# Altium schematic sheet main.SchDoc(main)
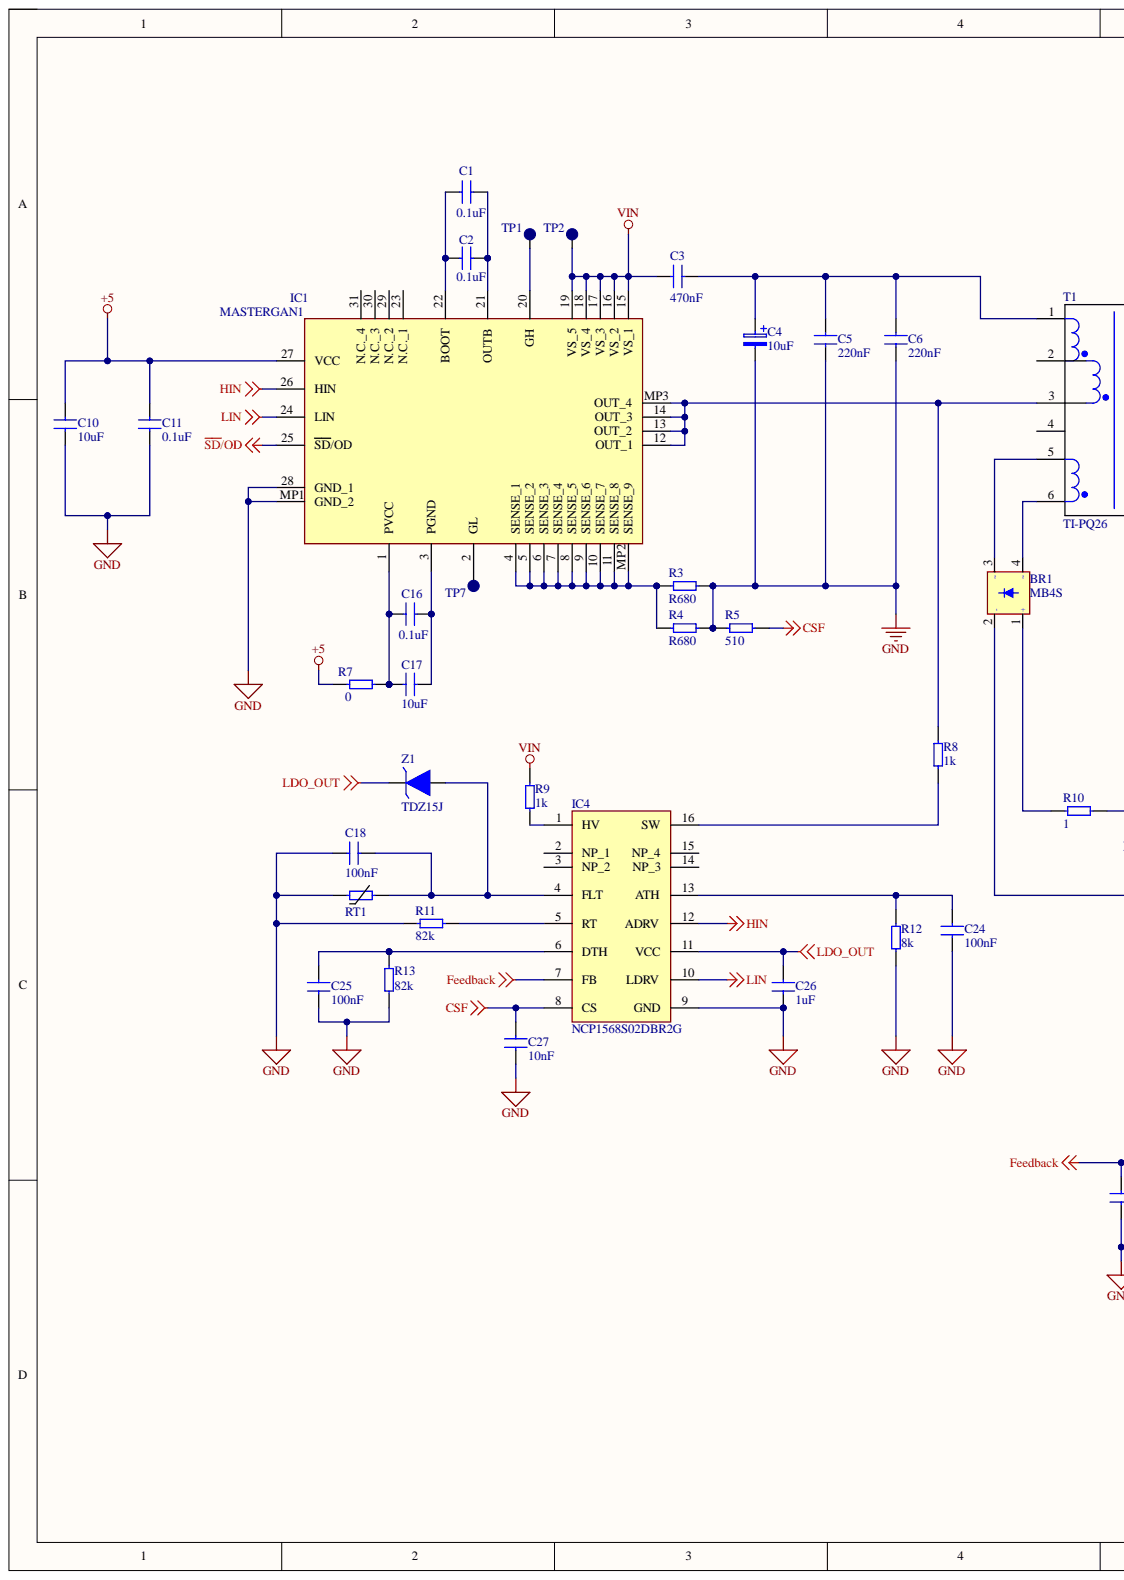
[diagram p0: main.SchDoc, left]
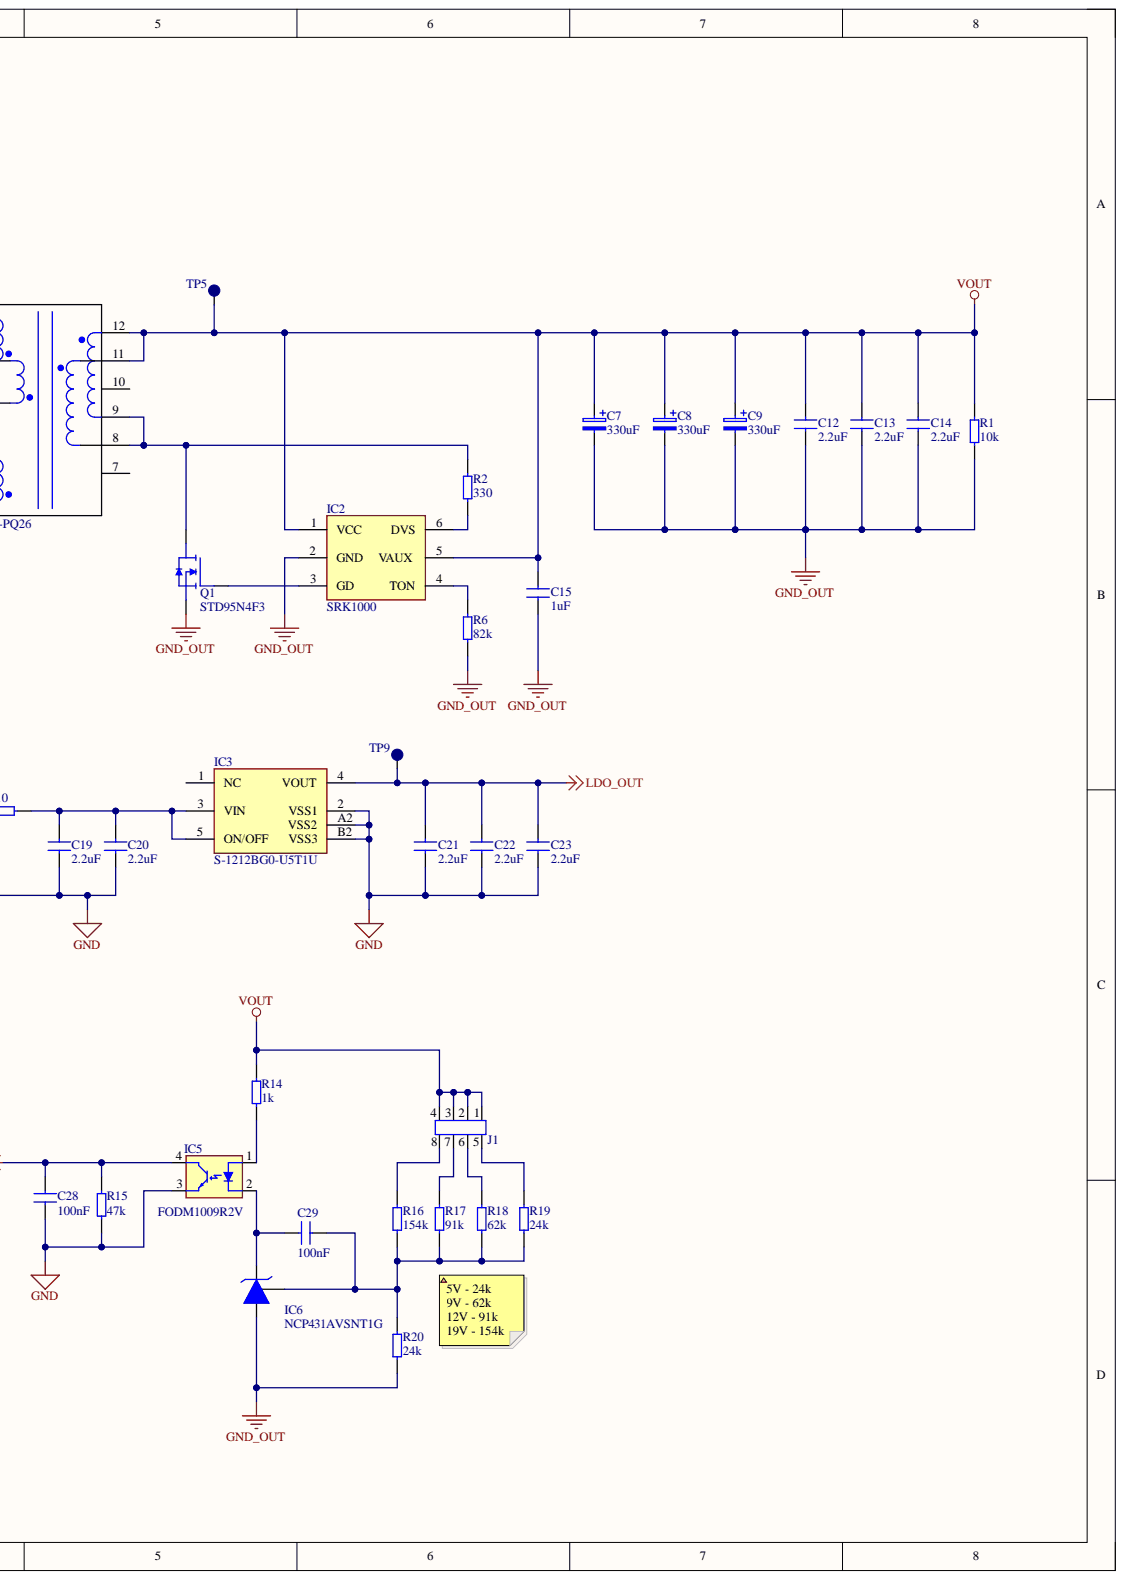
[diagram p1: main.SchDoc, right]

## Parts
BR1  MB4S  [SOP245P670X290-4N]
C1  0.1uF  [Capcitor_1206]
C2  0.1uF  [Capcitor_1206]
C3  470nF  [Capacitor_1812]
C4  10uF  [Capacitor_Electrolytic]
C5  220nF  [Capacitor_1812]
C6  220nF  [Capacitor_1812]
C7  330uF  [Capacitor_Electrolytic]
C8  330uF  [Capacitor_Electrolytic]
C9  330uF  [Capacitor_Electrolytic]
C10  10uF  [Capcitor_1206]
C11  0.1uF  [Capcitor_1206]
C12  2.2uF  [Capcitor_1206]
C13  2.2uF  [Capcitor_1206]
C14  2.2uF  [Capcitor_1206]
C15  1uF  [Capcitor_1206]
C16  0.1uF  [Capcitor_1206]
C17  10uF  [Capcitor_1206]
C18  100nF  [Capcitor_1206]
C19  2.2uF  [Capcitor_1206]
C20  2.2uF  [Capcitor_1206]
C21  2.2uF  [Capcitor_1206]
C22  2.2uF  [Capcitor_1206]
C23  2.2uF  [Capcitor_1206]
C24  100nF  [Capcitor_1206]
C25  100nF  [Capcitor_1206]
C26  1uF  [Capacitor_0805]
C27  10nF  [Capcitor_1206]
C28  100nF  [Capcitor_1206]
C29  100nF  [Capcitor_1206]
IC1  MASTERGAN1  [MASTERGAN1]
IC2  SRK1000  [SOT95P280X145-6N]
IC3  S-1212BG0-U5T1U  [S1212BG0U5T1U]
IC4  NCP1568S02DBR2G  [SOIC127P600X175-16N]
IC5  FODM1009R2V  [FODM1009R2V]
IC6  NCP431AVSNT1G  [SOT96P237X111-3N]
J1  Header_2x4  [Header_2x4]  (value not drawn)
Q1  STD95N4F3  [STD95N4F3]
R1  10k  [Resistor_1206]
R2  330  [Resistor_1206]
R3  R680  [Resistor_1206]
R4  R680  [Resistor_1206]
R5  510  [Resistor_1206]
R6  82k  [Resistor_1206]
R7  0  [Resistor_0805]
R8  1k  [Resistor_1206]
R9  1k  [Resistor_1206]
R10  1  [Resistor_1206]
R11  82k  [Resistor_1206]
R12  8k  [Resistor_1206]
R13  82k  [Resistor_1206]
R14  1k  [Resistor_1206]
R15  47k  [Resistor_1206]
R16  154k  [Resistor_0805]
R17  91k  [Resistor_0805]
R18  62k  [Resistor_0805]
R19  24k  [Resistor_0805]
R20  24k  [Resistor_1206]
RT1  NTCG104EF104FT1SX  [THRMC1005X55N]  (value not drawn)
T1  TI-PQ26  [PQ26-20-K]
TP1  =Value  [Test point]  (no BOM)
TP2  =Value  [Test point]  (no BOM)
TP5  =Value  [Test point]  (no BOM)
TP7  =Value  [Test point]  (no BOM)
TP9  =Value  [Test point]  (no BOM)
Z1  TDZ15J  [SODFL4725X105N]

## Nets
+5: C10.2 C11.1 IC1.27(VCC) R7.1
~{SD}/OD: IC1.25(SD/OD)
CSF: C27.1 IC4.8(CS) R5.1
Feedback: C28.2 IC4.7(FB) IC5.4 R15.2
GND: BR1.2(-) C4.2 C5.2 C6.1 C10.1 C11.2 C18.1 C19.1 C20.1 C21.1 C22.1 C23.1 C24.1 C25.2 C26.1 C27.2 C28.1 IC1.28(GND_1) IC1.MP1(GND_2) IC3.2(VSS1) IC3.A2(VSS2) IC3.B2(VSS3) IC4.9(GND) IC5.3 R3.2 R4.2 R5.2 R11.2 R12.1 R13.2 R15.1 RT1.1
GND_OUT: C7.2 C8.2 C9.2 C12.1 C13.1 C14.1 C15.1 IC2.2(GND) IC6.3 Q1.2 R1.1 R6.1 R20.1
HIN: IC1.26(HIN) IC4.12(ADRV)
LDO_OUT: C21.2 C22.2 C23.2 C26.2 IC3.4(VOUT) IC4.11(VCC) TP9.1 Z1.1
LIN: IC1.24(LIN) IC4.10(LDRV)
VIN: C3.1 IC1.15(VS_1) IC1.16(VS_2) IC1.17(VS_3) IC1.18(VS_4) IC1.19(VS_5) R9.2 TP2.1
VOUT: C7.1 C8.1 C9.1 C12.2 C13.2 C14.2 C15.2 IC2.1(VCC) IC2.5(VAUX) J1.1 J1.2 J1.3 J1.4 R1.2 R14.2 T1.11 T1.12 TP5.1
NetBR1_1: BR1.1(+) R10.1
NetBR1_3: BR1.3(~) T1.5
NetBR1_4: BR1.4(~) T1.6
NetC1_1: C1.1 C2.1 IC1.22(BOOT)
NetC1_2: C1.2 C2.2 IC1.21(OUTB)
NetC3_2: C3.2 C4.1 C5.1 C6.2 T1.1
NetC16_1: C16.1 C17.1 IC1.1(PVCC) R7.2
NetC16_2: C16.2 C17.2 IC1.3(PGND)
NetC18_2: C18.2 IC4.4(FLT) RT1.2 Z1.2
NetC19_2: C19.2 C20.2 IC3.3(VIN) IC3.5(ON/OFF) R10.2
NetC24_2: C24.2 IC4.13(ATH) R12.2
NetC25_1: C25.1 IC4.6(DTH) R13.1
NetC29_1: C29.1 IC5.2 IC6.2
NetC29_2: C29.2 IC6.1 R16.1 R17.1 R18.1 R19.1 R20.2
NetIC1_2: IC1.2(GL) TP7.1
NetIC1_4: IC1.4(SENSE_1) IC1.5(SENSE_2) IC1.6(SENSE_3) IC1.7(SENSE_4) IC1.8(SENSE_5) IC1.9(SENSE_6) IC1.10(SENSE_7) IC1.11(SENSE_8) IC1.MP2(SENSE_9) R3.1 R4.1
NetIC1_12: IC1.12(OUT_1) IC1.13(OUT_2) IC1.14(OUT_3) IC1.MP3(OUT_4) R8.2 T1.3
NetIC1_20: IC1.20(GH) TP1.1
NetIC2_3: IC2.3(GD) Q1.1
NetIC2_4: IC2.4(TON) R6.2
NetIC2_6: IC2.6(DVS) R2.1
NetIC4_1: IC4.1(HV) R9.1
NetIC4_5: IC4.5(RT) R11.1
NetIC4_16: IC4.16(SW) R8.1
NetIC5_1: IC5.1 R14.1
NetJ1_5: J1.5 R19.2
NetJ1_6: J1.6 R18.2
NetJ1_7: J1.7 R17.2
NetJ1_8: J1.8 R16.2
NetQ1_3: Q1.3 R2.2 T1.8 T1.9

Not connected: IC1 23(N.C._1) 29(N.C._2) 30(N.C._3) 31(N.C._4); IC3 1(NC); IC4 2(NP_1) 3(NP_2) 14(NP_3) 15(NP_4); T1 2 4 7 10

## Library data of the parts
BR1 = MB4S: Bridge Rectifier; Manufacturer_Name: onsemi; Arrow Part Number: MB4S; Arrow Price/Stock: https://www.arrow.com/en/products/mb4s/on-semiconductor?region=europe; Component Kind: Standard; Datasheet Link: http://www.onsemi.com/pub/Collateral/MB8S-D.PDF; Height: 2.9mm; Manufacturer_Part_Number: MB4S; Mouser Part Number: 512-MB4S; Mouser Price/Stock: https://www.mouser.co.uk/ProductDetail/onsemi-Fairchild/MB4S?qs=DPwKKv7J1fyzyqMN%2FwPoFQ%3D%3D; Library Name: KN_ECART.SchLib
C1 C2 = Capacitor_1206: Capacitor - Standard SMD; Voltage: 25V; Capacitance: 0.1uF; Component Kind: Standard; Library Name: KN_ECART.SchLib
C3 = Capacitor_1812: Capacitor - Standard SMD; MPN: CGA8N4X7T2W474K230KA; Voltage: 450V; Capacitance: 470nF; Component Kind: Standard; Library Name: KN_ECART.SchLib
C4 = Capacitor_Electrolytic: Capacitor - Electrolytic  SMD; MPN: UUG2W100MNQ1ZD; Voltage: 450V; Capacitance: 10uF; Component Kind: Standard; Library Name: KN_ECART.SchLib
C5 C6 = Capacitor_1812: Capacitor - Standard SMD; MPN: C1812C224MCRACTU; Voltage: 500; Capacitance: 220nF; Component Kind: Standard; Library Name: KN_ECART.SchLib
C7-C9 = Capacitor_Electrolytic: Capacitor - Electrolytic  SMD; MPN: A770MS337M1VLAS022; Voltage: 35; Capacitance: 330uF; Component Kind: Standard; Library Name: KN_ECART.SchLib
C10 C11 C16 C17 = Capacitor_1206: Capacitor - Standard SMD; Voltage: 16V; Component Kind: Standard; Library Name: KN_ECART.SchLib
  C10 C17: Capacitance: 10uF
  C11 C16: Capacitance: 0.1uF
C12-C14 C19-C23 = Capacitor_1206: Capacitor - Standard SMD; MPN: CL31B225KBHNFNE; Voltage: 50V; Capacitance: 2.2uF; Component Kind: Standard; Library Name: KN_ECART.SchLib
C15 = Capacitor_1206: Capacitor - Standard SMD; MPN: CL31B105KBHNFNE; Voltage: 50; Capacitance: 1uF; Component Kind: Standard; Library Name: KN_ECART.SchLib
C18 C24 C25 C27-C29 = Capacitor_1206: Capacitor - Standard SMD; Voltage: 50V; Component Kind: Standard; Library Name: KN_ECART.SchLib
  C18 C24 C25 C28 C29: Capacitance: 100nF
  C27: Capacitance: 10nF
C26 = Capacitor_0805: Capacitor - Standard SMD; Voltage: 25V; Capacitance: 1uF; Component Kind: Standard; Library Name: KN_ECART.SchLib
IC1 = MASTERGAN1: Integrated Circuit; Manufacturer_Name: STMicroelectronics; Arrow Part Number: MASTERGAN1; Arrow Price/Stock: https://www.arrow.com/en/products/mastergan1/stmicroelectronics?region=nac; Component Kind: Standard; Datasheet Link: https://www.mouser.com/datasheet/2/389/mastergan1-1893186.pdf; Height: 1mm; Manufacturer_Part_Number: MASTERGAN1; Mouser Part Number: 511-MASTERGAN1; Mouser Price/Stock: https://www.mouser.co.uk/ProductDetail/STMicroelectronics/MASTERGAN1?qs=hWgE7mdIu5TUQlvSzk235A%3D%3D; Library Name: KN_ECART.SchLib
IC2 = SRK1000: Integrated Circuit; Manufacturer_Name: STMicroelectronics; Arrow Part Number: SRK1000; Arrow Price/Stock: https://www.arrow.com/en/products/srk1000/stmicroelectronics; Component Kind: Standard; Datasheet Link: https://www.st.com/resource/en/datasheet/srk1000.pdf; Height: 1.45mm; Manufacturer_Part_Number: SRK1000; Mouser Part Number: 511-SRK1000; Mouser Price/Stock: https://www.mouser.co.uk/ProductDetail/STMicroelectronics/SRK1000?qs=byeeYqUIh0P1pUk%2FLXsjaA%3D%3D; Library Name: KN_ECART.SchLib
IC3 = S-1212BG0-U5T1U: Integrated Circuit; Manufacturer_Name: ABLIC Inc.; Component Kind: Standard; Datasheet Link: https://www.mouser.com/datasheet/2/360/S1212B_D_E-1480071.pdf; Height: 1.6mm; Manufacturer_Part_Number: S-1212BG0-U5T1U; Mouser Part Number: 628-S-1212BG0-U5T1U; Mouser Price/Stock: https://www.mouser.co.uk/ProductDetail/ABLIC/S-1212BG0-U5T1U?qs=PqoDHHvF648vInS7ukjfAw%3D%3D; Library Name: KN_ECART.SchLib
IC4 = NCP1568S02DBR2G: Integrated Circuit; Manufacturer_Name: onsemi; Arrow Part Number: NCP1568S02DBR2G; Arrow Price/Stock: https://www.arrow.com/en/products/ncp1568s02dbr2g/on-semiconductor?region=nac; Component Kind: Standard; Datasheet Link: https://datasheet.datasheetarchive.com/originals/distributors/Datasheets_SAMA/e6c73cfd9b14743cc4dd16ca5df3e455.pdf; Height: 1.35mm; Manufacturer_Part_Number: NCP1568S02DBR2G; Mouser Part Number: 863-NCP1568S02DBR2G; Mouser Price/Stock: https://www.mouser.co.uk/ProductDetail/onsemi/NCP1568S02DBR2G?qs=BJlw7L4Cy7%252Bni9d8vX6s0A%3D%3D; Library Name: KN_ECART.SchLib
IC5 = FODM1009R2V: Integrated Circuit; Manufacturer_Name: onsemi; Arrow Part Number: FODM1009R2V; Arrow Price/Stock: https://www.arrow.com/en/products/fodm1009r2v/on-semiconductor?region=nac; Component Kind: Standard; Datasheet Link: https://componentsearchengine.com/Datasheets/1/FODM1009R2V.pdf; Height: 2.4mm; Manufacturer_Part_Number: FODM1009R2V; Mouser Part Number: 863-FODM1009R2V; Mouser Price/Stock: https://www.mouser.co.uk/ProductDetail/onsemi/FODM1009R2V?qs=d0WKAl%252BL4Kbb4VetG0t5Tw%3D%3D; Library Name: KN_ECART.SchLib
IC6 = NCP431AVSNT1G: Programmable Precision  Reference; Manufacturer_Name: onsemi; Arrow Part Number: NCP431AVSNT1G; Arrow Price/Stock: https://www.arrow.com/en/products/ncp431avsnt1g/on-semiconductor; Component Kind: Standard; Datasheet Link: http://www.onsemi.com/pub/Collateral/NCP431-D.PDF; Height: 1.11mm; Manufacturer_Part_Number: NCP431AVSNT1G; Mouser Part Number: 863-NCP431AVSNT1G; Mouser Price/Stock: https://www.mouser.com/Search/Refine.aspx?Keyword=863-NCP431AVSNT1G; Library Name: KN_ECART.SchLib
Q1 = STD95N4F3: MOSFET (N-Channel); Manufacturer_Name: STMicroelectronics; Arrow Part Number: STD95N4F3; Arrow Price/Stock: https://www.arrow.com/en/products/std95n4f3/stmicroelectronics; Component Kind: Standard; Datasheet Link: https://www.st.com/resource/en/datasheet/std95n4f3.pdf; Height: 2.4mm; Manufacturer_Part_Number: STD95N4F3; Mouser Part Number: 511-STD95N4F3; Mouser Price/Stock: https://www.mouser.co.uk/ProductDetail/STMicroelectronics/STD95N4F3?qs=Djavi1aLnwoepdJqDxyf1g%3D%3D; Library Name: KN_ECART.SchLib
R1 R2 R5 R6 R8-R15 R20 = Resistor_1206: Resistor - Standard SMD; Component Kind: Standard; Library Name: KN_ECART.SchLib
  R1: Resistance: 10k
  R2: Resistance: 330
  R5: Resistance: 510
  R6 R11 R13: Resistance: 82k
  R8 R9 R14: Resistance: 1k
  R10: Resistance: 1
  R12: Resistance: 8k
  R15: Resistance: 47k
  R20: Resistance: 24k
R3 R4 = Resistor_1206: Resistor - Standard SMD; MPN: PE1206FRF7W0R68L; Power rate: 0.5 W; Component Kind: Standard; Resistance: R680; Library Name: KN_ECART.SchLib
R7 R16-R19 = Resistor_0805: Resistor - Standard SMD; Component Kind: Standard; Library Name: KN_ECART.SchLib
  R7: Resistance: 0
  R16: Resistance: 154k
  R17: Resistance: 91k
  R18: Resistance: 62k
  R19: Resistance: 24k
RT1 = NTCG104EF104FT1SX: Thermistor; Manufacturer_Name: TDK; Arrow Part Number: NTCG104EF104FT1SX; Arrow Price/Stock: https://www.arrow.com/en/products/ntcg104ef104ft1sx/tdk; Component Kind: Standard; Datasheet Link: https://product.tdk.com/system/files/dam/doc/product/sensor/ntc/chip-ntc-thermistor/catalog/tpd_automotive_ntc-thermistor_ntcg_en.pdf; Height: 0.55mm; Manufacturer_Part_Number: NTCG104EF104FT1SX; Mouser Part Number: 810-NTC104EF104FT1SX; Mouser Price/Stock: https://www.mouser.co.uk/ProductDetail/TDK/NTCG104EF104FT1SX?qs=cNoPOocMWw25zdFQn%252B2tmg%3D%3D; Library Name: KN_ECART.SchLib
T1 = TI-PQ26: Transformer; MPN: TI-PQ26/20-EPR11; Component Kind: Standard; HelpURL: https://dokumentacja.feryster.pl/rysuj.php?indeks=76996816298acf4170f50a7b81faccb4; Value: TI-PQ26; Library Name: KN_ECART.SchLib
TP1 TP2 TP5 TP7 TP9 = Test point: Library Name: KN_ECART.SchLib
Z1 = TDZ15J: Zener Diode; Manufacturer_Name: Nexperia; Arrow Part Number: TDZ3V3J,115; Arrow Price/Stock: https://www.arrow.com/en/products/tdz3v3j115/nexperia; Component Kind: Standard; Datasheet Link: https://assets.nexperia.com/documents/data-sheet/TDZXJ_SER.pdf; Height: 0.8mm; Manufacturer_Part_Number: TDZ15J; Mouser Price/Stock: https://www.mouser.co.uk/ProductDetail/Nexperia/TDZ3V3J115?qs=A1cBxND5mHI2cVlA%2FVFCtg%3D%3D; Library Name: KN_ECART.SchLib
J1 = Header_2x4: Component Kind: Standard; Library Name: KN_ECART.SchLib
